# Altium schematic sheet Linear_Power.SchDoc
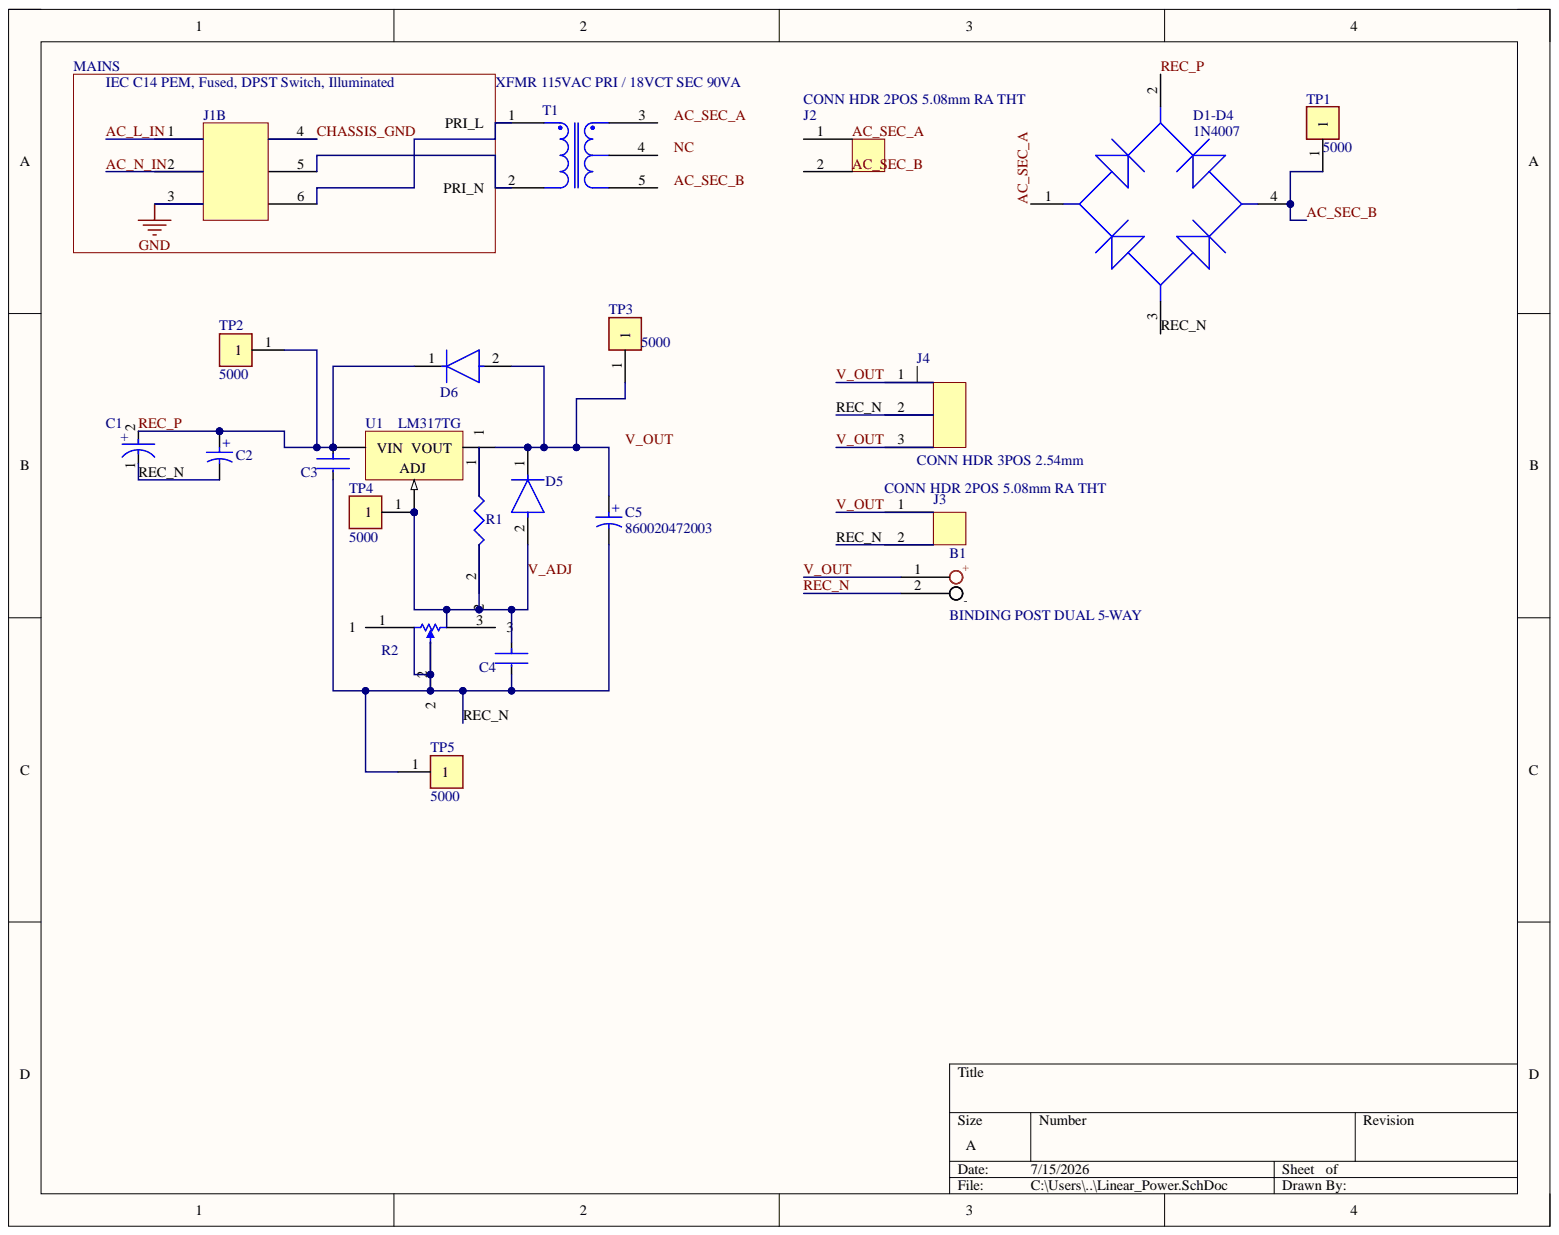
[diagram p0: Linear_Power.SchDoc]

## Parts
B1  BINDING POST DUAL 5-WAY
C1  UPW1V222MHD6  [FP-227AVG035MFBJ-MFG]  (value not drawn)
C2  UPJ1V101MPD  [WCAP-ATLI_D5H11]  (value not drawn)
C3  C410C104Z5U5TA7200  [FP-RHE0K1-MFG]  (value not drawn)
C4  C410C104Z5U5TA7200  [FP-RHE0K1-MFG]  (value not drawn)
C5  860020472003  [WCAP-ATG5_D5H11]
D1-D4  1N4007  [FP-KBJ-MFG]
D5  1N4007  [FP-1N4007-MFG]  (value not drawn)
D6  1N4007  [FP-1N4007-MFG]  (value not drawn)
J1  IEC C14 PEM, Fused, DPST Switch, Illuminated  (part B)
J2  CONN HDR 2POS 5.08mm RA THT  [OSTOQ025451]
J3  CONN HDR 2POS 5.08mm RA THT  [OSTOQ025451]
J4  CONN HDR 3POS 2.54mm  [Connector, header vertical 3-pos 2.54mm]
R1  240 Ohm,1/4W,1%  [pp]  (value not drawn)
R2  Potentiometer linear 4.7 kOhm±20 %,  [PC16SH07IP04-472A2020--TA]  (value not drawn)
T1  XFMR 115VAC PRI / 18VCT SEC 90VA
TP1  5000  [FP-5000-MFG]
TP2  5000  [FP-5000-MFG]
TP3  5000  [FP-5000-MFG]
TP4  5000  [FP-5000-MFG]
TP5  5000  [FP-5000-MFG]
U1  LM317TG  [ONSC-TO-220-3-221AB-01]

## Nets
GND: J1.3
AC_L_IN: J1.1
AC_N_IN: J1.2
AC_SEC_A: D1-D4.1 J2.1
AC_SEC_B: D1-D4.4 J2.2 TP1.1
CHASSIS_GND: J1.4
REC_N: C1.1 C2.2 C3.2 C4.2 C5.2 D1-D4.3 J3.2 J4.2 R2.1 R2.2 TP5.1
REC_P: C1.2 C2.1 C3.1 D1-D4.2 D6.1 TP2.1 U1.3
V_ADJ: C4.1 D5.2 R1.2 R2.3 TP4.1 U1.1
V_OUT: J3.1 J4.1 J4.3
NetC5_1: C5.1 D5.1 D6.2 TP3.1 U1.2
NC:

Not connected: B1 1 2; J1 5 6; R1 1; T1 1 2 3 4 5

## Library data of the parts
B1 = BU-P6883: Component Kind: Standard; Library Name: Schlib1.SchLib
C1 = CMP-00087-58146014-1: 227AVG035MFBJ AVG Series 35 V 220 uF 8 x 12 mm LS=3.5 mm Radial Aluminum Polymer Capacitor; Capacitance: 220µF; Dissipation Factor: 12%; ESR (Equivalent Series Resistance): 26mΩ; Leakage Current: 1.54mA; Life (Hours): 1500hours; Ripple Current: 2.95A; Tolerance: 20%; Voltage Rating: 35V; Case/Package: Radial; Component Kind: Standard; Height: 13.5mm; Library Name: Altium Content Vault; Max Operating Temperature: 125°C; Min Operating Temperature: -55°C; NexarPartId: 58146014; RoHS: Non-Compliant; Voltage Rating (DC): 35V
C2 = CMP-03913-54007346-2: WCAP-ATLI Aluminum Electrolytic Capacitors; Manufacturer Lifecycle Status: ACTIVE; Capacitance: 10µF; Impedance: 950mΩ; Leakage Current: 6.3µA; Life (Hours): 2000hours; Material: Aluminium; Pitch: 2mm; Polarity: Polar; Ripple Current: 135mA; Tolerance: 20%; Voltage Rating: 63V; YEOL: 1 = Estimated lifetime > 10 years; Case/Package: Radial; Component Kind: Standard; Diameter: 5mm; Height: 11mm; Height - Seated (Max): 12.4968mm; Lead Pitch: 2.0066mm; Length: 11mm; Library Name: Altium Content Vault; Lifecycle Status: Production; Max Operating Temperature: 105°C; Min Operating Temperature: -55°C; Mount: Through Hole; Packaging: Tape & Box (TB); REACH SVHC: Unknown; RoHS: Compliant; Voltage Rating (DC): 63V
C3 C4 = CMP-06035-006182-1: Multilayer Ceramic Capacitor, 0.1 uF, 50 V, -20%, +80%, Z5U, Axial Leaded; Component Kind: Standard; Library Name: Altium Content Vault
C5 = CMP-03913-53914185-1: 860020472003 WCAP-ATG5 Aluminum Electrolytic Capacitors; Capacitance: 10µF; Leakage Current: 3µA; Life (Hours): 2000hours; Pitch: 2mm; Polarity: Polar; Ripple Current: 38mA; Tolerance: 20%; Voltage Rating: 25V; Case/Package: Radial; Component Kind: Standard; Diameter: 5mm; Height - Seated (Max): 12.4968mm; Lead Pitch: 2.0066mm; Length: 11mm; Library Name: Altium Content Vault; Max Operating Temperature: 105°C; Min Operating Temperature: -40°C; Mount: Through Hole; NexarPartId: 53914185; Packaging: Tape & Box (TB); RoHS: Compliant
D1-D4 = CMP-12791-000017-1: BRIDGE RECT 1PHASE 800V 6A KBJ; Manufacturer: Diodes; Manufacturer Part Number: KBJ608G; Capacitance: 80pF; Forward Current: 3A; Max Junction Temp: +150°C; Max Surge Forward Current: 170A; Peak Reverse Current: 5uA; Standoff Height: 3.3mm; Value: 80pF; Automotive: No; Category: Diode; Component Kind: Standard; ComponentLink2Description: Datasheet; ComponentLink2URL: https://www.diodes.com/assets/Datasheets/ds21207.pdf; Device Class L1: Discrete Semiconductors; Device Class L2: Diodes; Device Class L3: Rectifier Diodes; Digikey Description: BRIDGE RECT 1PHASE 800V 6A KBJ; Footprint Url: https://www.diodes.com/assets/Package-Files/KBJ.pdf; Height: 19mm; Lead Free: Yes; Library Name: Altium Content Vault; Max Repetitive Reverse Voltage: 800V; Mouser Description: Bridge Rectifiers 6.0A 800V; Octopart: https://octopart.com/kbj608g-diodes+inc.-13390835; Package: KBJ; Reverse Voltage: 800V; RoHS: TRUE; Temperature: -65°C to +150°C; Temperature Range High: +150°C; Temperature Range Low: -65°C
D5 D6 = CMP-123542-000001-1: Rectifier diode 1000 V 1 A DO-41, ,; Manufacturer: Diotec; Capacitance: 15pF; Forward Current: 1A; Max Junction Temp: +175°C; Max Repetitive Reverse Voltage: 1000V; Max Surge Forward Current: 27A; Peak Reverse Current: 5uA; Value: 15pF; Automotive: No; Category: Diode; Component Kind: Standard; ComponentLink2Description: Datasheet; ComponentLink2URL: https://datasheet.octopart.com/1N4007-Diotec-datasheet-62346875.pdf; Device Class L1: Discrete Semiconductors; Device Class L2: Diodes; Device Class L3: Rectifier Diodes; Height: 2.8mm; Ipc Land Pattern Name: DIOAD888W100L520D280; Lead Free: Yes; Library Name: Altium Content Vault; Manufacturer Part Number: 1N4007; Octopart: https://octopart.com/1n4007-diotec-4040411; Package: 1N4007; Reverse Voltage: 1000V; RoHS: TRUE; Temperature: -50°C to +175°C; Temperature Range High: +175°C; Temperature Range Low: -50°C
J1 = 719W-UEL3BR51: Mains Power Connector; Manufacturer: Qualtek; Category: Power Connectors; Component Kind: Standard; Export Control Classification Number (ECCN) Code: EAR99; Harmonized Tariff Schedule (HTS) Code: 8536.69.40.30; Introduction Date: 2024-04-05; Library Name: Schlib1.SchLib; Lifecycle Status: Production; Manufacturer Part Number: 719W-UEL3BR51; Pricing: 1=7.66, 10=6.506, 30=6.02, 50=5.8068, 100=5.5296, 250=5.18348, 500=4.93622, 1000=4.70083 (USD); RoHS: Compliant; Stock: 363; Supplier: DigiKey; Supplier Part Number: 189-719W-UEL3BR51-ND
J2 J3 = OSTOQ025451: 2-position, 5.08mm pitch, shrouded, right-angle, through-hole header; Component Kind: Standard; Library Name: Schlib1.SchLib
J4 = PRPC003SAAN-RC: Header, 3-position, 0.1in pitch, straight, TH; Component Kind: Standard; Library Name: Schlib1.SchLib
R1 = RNMF14FTC240R: Through Hole Resistor 240 Ohm,1/4W,1%, Axial; Component Kind: Standard; Library Name: Schlib1.SchLib
R2 = PC16SH07IP04-472A2020--TA: Potentiometer linear 4.7 kOhm±20 %, PC16SH07IP04-472A2020--TA, Piher; Tolerance: 20%; Value: 4.7kΩ; Category: Variable Resistors and Potentiometers; Component Kind: Standard; Composition: Carbon; Library Name: Schlib1.SchLib; Manufacturer: Piher; Manufacturer Part Number: PC16SH07IP04-472A2020--TA; Mounting Technology: Through Hole; Pricing: 1=2.71, 10=2.37, 25=2.26, 50=2.13, 100=1.96, 250=1.91, 500=1.8, 1000=1.6 (USD); RoHS: Compliant; Stock: 2313; Supplier: Newark; Supplier Part Number: PC16SH-07IP04-472A2020-TA
T1 = 166P18: Power transformer, 115VAC primary, 18V center-tapped secondary, 5A, 90VA, chassis mount, wire leads; Manufacturer: Hammond; Approvals: CSA, UL; Frequency: 60Hz; Isolation Voltage: 2000Vrms; Max Power Dissipation: 90W; Nominal Supply Voltage: 115V; Primary Winding(s): Single; Category: Transformers; Component Kind: Standard; ComponentLink1Description: https://datasheet.octopart.com:443/166P18-Hammond-datasheet-179918239.pdf?src-supplier=IHS; ComponentLink1URL: https://datasheet.octopart.com:443/166P18-Hammond-datasheet-179918239.pdf?src-supplier=IHS; ComponentLink2Description: https://datasheet.octopart.com:443/166P18-Hammond-datasheet-8450255.pdf?src-supplier=Master+Electronics; ComponentLink2URL: https://datasheet.octopart.com:443/166P18-Hammond-datasheet-8450255.pdf?src-supplier=Master+Electronics; ComponentLink3Description: https://datasheet.octopart.com:443/166P18-Hammond-datasheet-78727889.pdf?src-supplier=Newark; ComponentLink3URL: https://datasheet.octopart.com:443/166P18-Hammond-datasheet-78727889.pdf?src-supplier=Newark; ComponentLink4Description: https://datasheet.octopart.com:443/166P18-Hammond-datasheet-1636.pdf?src-supplier=DigiKey; ComponentLink4URL: https://datasheet.octopart.com:443/166P18-Hammond-datasheet-1636.pdf?src-supplier=DigiKey; ComponentLink5Description: https://datasheet.octopart.com:443/166P18-Hammond-datasheet-154734928.pdf?src-supplier=Electro+Sonic; ComponentLink5URL: https://datasheet.octopart.com:443/166P18-Hammond-datasheet-154734928.pdf?src-supplier=Electro+Sonic; ComponentLink6Description: https://datasheet.octopart.com:443/166P18-Hammond-datasheet-9571880.pdf?src-supplier=RS+(Formerly+Allied+Electronics); ComponentLink6URL: https://datasheet.octopart.com:443/166P18-Hammond-datasheet-9571880.pdf?src-supplier=RS+(Formerly+Allied+Electronics); ComponentLink7Description: https://datasheet.octopart.com:443/166P18-Hammond-datasheet-16756.pdf?src-supplier=RS+(Formerly+Allied+Electronics); ComponentLink7URL: https://datasheet.octopart.com:443/166P18-Hammond-datasheet-16756.pdf?src-supplier=RS+(Formerly+Allied+Electronics); ComponentLink8Description: https://datasheet.octopart.com:443/166P18-Hammond-datasheet-17018915.pdf?src-supplier=Factory+Futures; ComponentLink8URL: https://datasheet.octopart.com:443/166P18-Hammond-datasheet-17018915.pdf?src-supplier=Factory+Futures; ComponentLink9Description: http://sigma.octopart.com:80/conflict_mineral_statement/Hammond-company-48.pdf?src-supplier=Compliance+Statements; ComponentLink9URL: http://sigma.octopart.com:80/conflict_mineral_statement/Hammond-company-48.pdf?src-supplier=Compliance+Statements; Current Rating: 5A; Height: 66.802mm; Height - Seated (Max): 66.8mm; Length: 102.362mm; Library Name: Schlib1.SchLib; Lifecycle Status: Production; Manufacturer Part Number: 166P18; Max Operating Temperature: 130°C; Max Output current: 5A; Min Operating Temperature: 0°C; Mounting Technology: Chassis Mount; Output Current: 5A; Output Voltage: 18V; Packaging: Bulk; Power Rating: 90W; Pricing: 1=44.28, 5=42.04, 10=39.81, 25=37.85, 50=36.92, 100=33.62, 250=32.97 (USD); REACH SVHC: Yes; RoHS: Compliant; Schedule B: 8504314035; Secondary Winding(s): Single; Stock: 34; Supplier: Newark; Supplier Part Number: 166P18; Termination: Wire Leads; Width: 63.5mm
TP1-TP5 = CMP-19636-000027-1: PC TEST POINT MINIATURE RED; Body Material: Bronze; Hole Diameter: 1.6mm; Inside Diameter: 1.27mm; Insulation: Insulated; Number of Contacts: 1; Outside Diameter: 2.54mm; Plating: Silver; Color: Red; Component Kind: Standard; Contact Material: Bronze; Contact Plating: Tin; Flammability Rating: UL94 V-0; Height: 0.3inch; Housing Color: Red; Lead Free: Lead Free; Length: 7.62mm; Library Name: Altium Content Vault; Material: Nylon; Mount: PCB; Number of Ports: 1; Orientation: Straight; Package Quantity: 100; Packaging: Bulk; REACH SVHC: No SVHC; RoHS Compliant: Yes; Series: THM
U1 = CMP-1679-00013-1: 1.5 A Adjustable Output, Positive Voltage Regulator, 0 to 125 degC, 3-Pin TO-220 (221AB-01), Pb-Free, Rail; Current Rating: 500 mA; Component Kind: Standard; ComponentLink1Description: Manufacturer URL; ComponentLink1URL: http://www.onsemi.com/; ComponentLink2Description: Datasheet; ComponentLink2URL: http://www.onsemi.com/pub_link/Collateral/LM317-D.PDF; Contact Finish: Gold; Contact Material: Copper; Contact Plating: Gold; DatasheetVersion: Rev. 14, 01/2016; Height: 2.95 mm; Lead Pitch: 2.5 mm; Length: 27.4 mm; Library Name: Altium Content Vault; Max Operating Temperature: 85degC; Min Operating Temperature: -25degC; Mount: Surface Mount; Package Quantity: 500; PackageDescription: 3-Pin Flange Mount, Vertical Straight Lead, Body 9.97 x 4.445 mm, Pitch 2.54 mm; PackageReference: TO-220-3-221AB-01; PackageVersion: Rev. A, 11/2010; Packaging: Tape and Reel; Pins: 9; Radiation Hardening: No; REACH SVHC: Unknown; RoHSCompliant: True
